# Altium schematic sheet STM32F10RBT6通用开发板_MCU.SchDoc
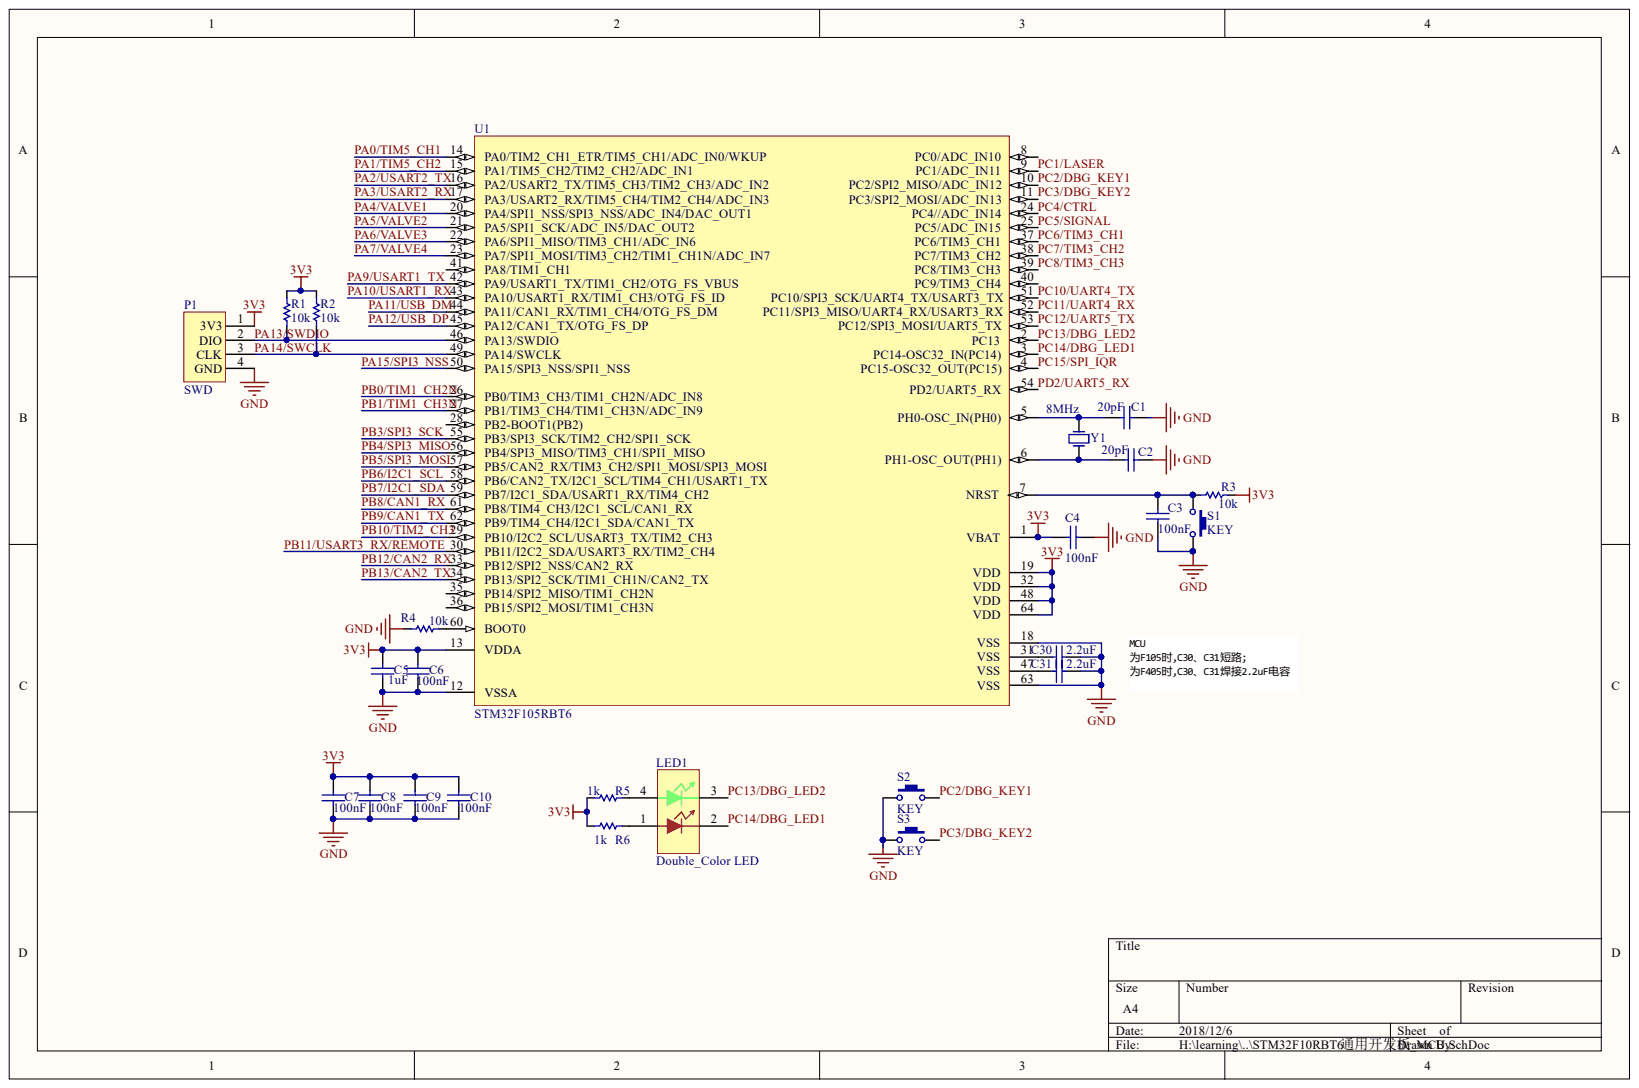
[diagram p0: STM32F10RBT6通用开发板_MCU.SchDoc]

## Parts
C1  20pF  [0603C]
C2  20pF  [0603C]
C3  100nF  [0603C]
C4  100nF  [0603C]
C5  1uF  [0603C]
C6  100nF  [0603C]
C7  100nF  [0603C]
C8  100nF  [0603C]
C9  100nF  [0603C]
C10  100nF  [0603C]
C30  2.2uF  [0603C]
C31  2.2uF  [0603C]
LED1  Double_Color LED  [0805LEDX2]
P1  SWD  [HEADER 4x1]
R1  10k  [0603R]
R2  10k  [0603R]
R3  10k  [0603R]
R4  10k  [0603R]
R5  1k  [0603R]
R6  1k  [0603R]
S1  KEY  [SW 3mmx4mm]
S2  KEY  [SW 3mmx4mm]
S3  KEY  [SW 3mmx4mm]
U1  STM32F105RBT6  [LQFP_64]
Y1  8MHz  [NX3225GD]

## Nets
3V3: C4.1 C5.1 C6.1 C7.1 C8.1 C9.1 C10.1 P1.1(3V3) R1.2 R2.2 R3.2 R5.2 R6.2 U1.1(VBAT) U1.13(VDDA) U1.19(VDD) U1.32(VDD) U1.48(VDD) U1.64(VDD)
GND: C1.2 C2.2 C3.2 C4.2 C5.2 C6.2 C7.2 C8.2 C9.2 C10.2 C30.2 C31.2 P1.4(GND) R4.2 S1.2 S2.1 S3.1 U1.12(VSSA) U1.18(VSS) U1.63(VSS)
PA0/TIM5_CH1: U1.14(PA0/TIM2_CH1_ETR/TIM5_CH1/ADC_IN0/WKUP)
PA1/TIM5_CH2: U1.15(PA1/TIM5_CH2/TIM2_CH2/ADC_IN1)
PA2/USART2_TX: U1.16(PA2/USART2_TX/TIM5_CH3/TIM2_CH3/ADC_IN2)
PA3/USART2_RX: U1.17(PA3/USART2_RX/TIM5_CH4/TIM2_CH4/ADC_IN3)
PA4/VALVE1: U1.20(PA4/SPI1_NSS/SPI3_NSS/ADC_IN4/DAC_OUT1)
PA5/VALVE2: U1.21(PA5/SPI1_SCK/ADC_IN5/DAC_OUT2)
PA6/VALVE3: U1.22(PA6/SPI1_MISO/TIM3_CH1/ADC_IN6)
PA7/VALVE4: U1.23(PA7/SPI1_MOSI/TIM3_CH2/TIM1_CH1N/ADC_IN7)
PA9/USART1_TX: U1.42(PA9/USART1_TX/TIM1_CH2/OTG_FS_VBUS)
PA10/USART1_RX: U1.43(PA10/USART1_RX/TIM1_CH3/OTG_FS_ID)
PA11/USB_DM: U1.44(PA11/CAN1_RX/TIM1_CH4/OTG_FS_DM)
PA12/USB_DP: U1.45(PA12/CAN1_TX/OTG_FS_DP)
PA13/SWDIO: P1.2(DIO) R1.1 U1.46(PA13/SWDIO)
PA14/SWCLK: P1.3(CLK) R2.1 U1.49(PA14/SWCLK)
PA15/SPI3_NSS: U1.50(PA15/SPI3_NSS/SPI1_NSS)
PB0/TIM1_CH2N: U1.26(PB0/TIM3_CH3/TIM1_CH2N/ADC_IN8)
PB1/TIM1_CH3N: U1.27(PB1/TIM3_CH4/TIM1_CH3N/ADC_IN9)
PB3/SPI3_SCK: U1.55(PB3/SPI3_SCK/TIM2_CH2/SPI1_SCK)
PB4/SPI3_MISO: U1.56(PB4/SPI3_MISO/TIM3_CH1/SPI1_MISO)
PB5/SPI3_MOSI: U1.57(PB5/CAN2_RX/TIM3_CH2/SPI1_MOSI/SPI3_MOSI)
PB6/I2C1_SCL: U1.58(PB6/CAN2_TX/I2C1_SCL/TIM4_CH1/USART1_TX)
PB7/I2C1_SDA: U1.59(PB7/I2C1_SDA/USART1_RX/TIM4_CH2)
PB8/CAN1_RX: U1.61(PB8/TIM4_CH3/I2C1_SCL/CAN1_RX)
PB9/CAN1_TX: U1.62(PB9/TIM4_CH4/I2C1_SDA/CAN1_TX)
PB10/TIM2_CH3: U1.29(PB10/I2C2_SCL/USART3_TX/TIM2_CH3)
PB11/USART3_RX/REMOTE: U1.30(PB11/I2C2_SDA/USART3_RX/TIM2_CH4)
PB12/CAN2_RX: U1.33(PB12/SPI2_NSS/CAN2_RX)
PB13/CAN2_TX: U1.34(PB13/SPI2_SCK/TIM1_CH1N/CAN2_TX)
PC1/LASER: U1.9(PC1/ADC_IN11)
PC2/DBG_KEY1: S2.2 U1.10(PC2/SPI2_MISO/ADC_IN12)
PC3/DBG_KEY2: S3.2 U1.11(PC3/SPI2_MOSI/ADC_IN13)
PC4/CTRL: U1.24(PC4//ADC_IN14)
PC5/SIGNAL: U1.25(PC5/ADC_IN15)
PC6/TIM3_CH1: U1.37(PC6/TIM3_CH1)
PC7/TIM3_CH2: U1.38(PC7/TIM3_CH2)
PC8/TIM3_CH3: U1.39(PC8/TIM3_CH3)
PC10/UART4_TX: U1.51(PC10/SPI3_SCK/UART4_TX/USART3_TX)
PC11/UART4_RX: U1.52(PC11/SPI3_MISO/UART4_RX/USART3_RX)
PC12/UART5_TX: U1.53(PC12/SPI3_MOSI/UART5_TX)
PC13/DBG_LED2: LED1.3 U1.2(PC13)
PC14/DBG_LED1: LED1.2 U1.3(PC14-OSC32_IN(PC14))
PC15/SPI_IQR: U1.4(PC15-OSC32_OUT(PC15))
PD2/UART5_RX: U1.54(PD2/UART5_RX)
NetC1_1: C1.1 U1.5(PH0-OSC_IN(PH0)) Y1.2
NetC2_1: C2.1 U1.6(PH1-OSC_OUT(PH1)) Y1.1
NetC3_1: C3.1 R3.1 S1.1 U1.7(NRST)
NetC30_1: C30.1 U1.31(VSS)
NetC31_1: C31.1 U1.47(VSS)
NetLED1_1: LED1.1 R6.1
NetLED1_4: LED1.4 R5.1
NetR4_1: R4.1 U1.60(BOOT0)

Not connected: U1 8(PC0/ADC_IN10) 28(PB2-BOOT1(PB2)) 35(PB14/SPI2_MISO/TIM1_CH2N) 36(PB15/SPI2_MOSI/TIM1_CH3N) 40(PC9/TIM3_CH4) 41(PA8/TIM1_CH1)

## Library data of the parts
C1-C10 C30 C31 = Cap: Capacitor; Value: 100pF; Component Kind: Standard; LatestRevisionDate: 17-Jul-2002; LatestRevisionNote: Re-released for DXP Platform.; PackageDescription: Radial Cap, Thru-Hole; 2 Leads; 0.3 in Pin Spacing; PackageReference: RAD-0.3; Published: 8-Jun-2000; Signal Integrity: Cap; Simulation: CAP; Publisher: Altium Limited
  C1-C10: Library Name: MyComponents_v2.3.IntLib
  C30 C31: Library Name: MyComponents_v2.5.IntLib
P1 = SWD_HEADER: Component Kind: Standard; Library Name: MyComponents_v2.5.IntLib
R1-R4 = Res1: Resistor; Value: 1K; Component Kind: Standard; LatestRevisionDate: 17-Jul-2002; LatestRevisionNote: Re-released for DXP Platform.; Library Name: MyComponents_v2.3.IntLib; PackageDescription: Axial Device, Thru-Hole; 2 Leads; 0.3 in Pin Spacing; PackageReference: AXIAL-0.3; Published: 8-Jun-2000; Signal Integrity: Res; Simulation: RESISTOR; Publisher: Altium Limited
R5 R6 = Res1: Resistor; Component Kind: Standard; LatestRevisionDate: 17-Jul-2002; LatestRevisionNote: Re-released for DXP Platform.; Library Name: MyComponents_v2.3.IntLib; PackageDescription: Axial Device, Thru-Hole; 2 Leads; 0.3 in Pin Spacing; PackageReference: AXIAL-0.3; Published: 8-Jun-2000; Signal Integrity: Res; Simulation: RESISTOR; Value: 1K; Publisher: Altium Limited
S1-S3 = PushButton_2p: Button; Component Kind: Standard; LatestRevisionDate: 17-Jul-2002; LatestRevisionNote: Re-released for DXP Platform.; Library Name: MyComponents_v2.3.IntLib; PackageDescription: Switch; 2 Leads; PackageReference: SPST-2; Published: 24-Mar-1999; Publisher: Altium Limited
U1 = STM32F105RBT6: ARM Cortex-M4 32b MCU+FPU, 210DMIP, 1024 kB Flash, 192+4 kB RAM, 51 I/Os, 64-pin LQFP, -40 to +85癈, Tray; 16-bit Timers (IC/OC/PWM): 12x16-bit(24/24/30); 32-bit Timer (IC/OC/PWM): 2x32-bit (8/8/8); A/D Converter: 16x12-bit; D/A Converter: 2x12-bit; Internal RAM: 192kB; Operating Frequency (Processor Speed): 168MHz; Other Timer Functions: 2xWDG, RTC, 24-bit down counter; Serial Interface: 3xSPI/2xI2S/2xI2C/3xUSART(IrDa, ISO7816)/3xUART/1xUSB OTG FS/HS, 2xCAN/ SDIO; Component Kind: Standard; ComponentLink1Description: Manufacturer URL; ComponentLink1URL: http://www.st.com/; ComponentLink2Description: STM32F405/7 Datasheet; ComponentLink2URL: http://www.st.com/internet/com/TECHNICAL_RESOURCES/TECHNICAL_LITERATURE/DATASHEET/DM00037051.pdf; ComponentLink3Description: STM32F4 Web Page; ComponentLink3URL: http://www.st.com/internet/mcu/subclass/1521.jsp; DatasheetVersion: rev2, Jan-2012; Library Name: MyComponents_v2.5.IntLib; PackageDescription: 64-Pin Low Profile Quad Flat Package, 10x10 mm Body, 0.5 mm Pitch; PackageReference: TQFP64; PackageVersion: 2012
Y1 = XTAL: Crystal Oscillator; Component Kind: Standard; LatestRevisionDate: 17-Jul-2002; LatestRevisionNote: Re-released for DXP Platform.; Library Name: MyComponents_v2.3.IntLib; PackageDescription: Crystal, Thru-Hole; 2 Leads; Body 3.1 x 8.2 mm (Dia.xH); PackageReference: R38; Published: 8-Jun-2000; Simulation: XTAL; Publisher: Altium Limited
LED1 = Double_Color LED: Component Kind: Standard; Library Name: MyComponents_v2.5.IntLib
